# Altium schematic sheet Connector.SchDoc(Connector)
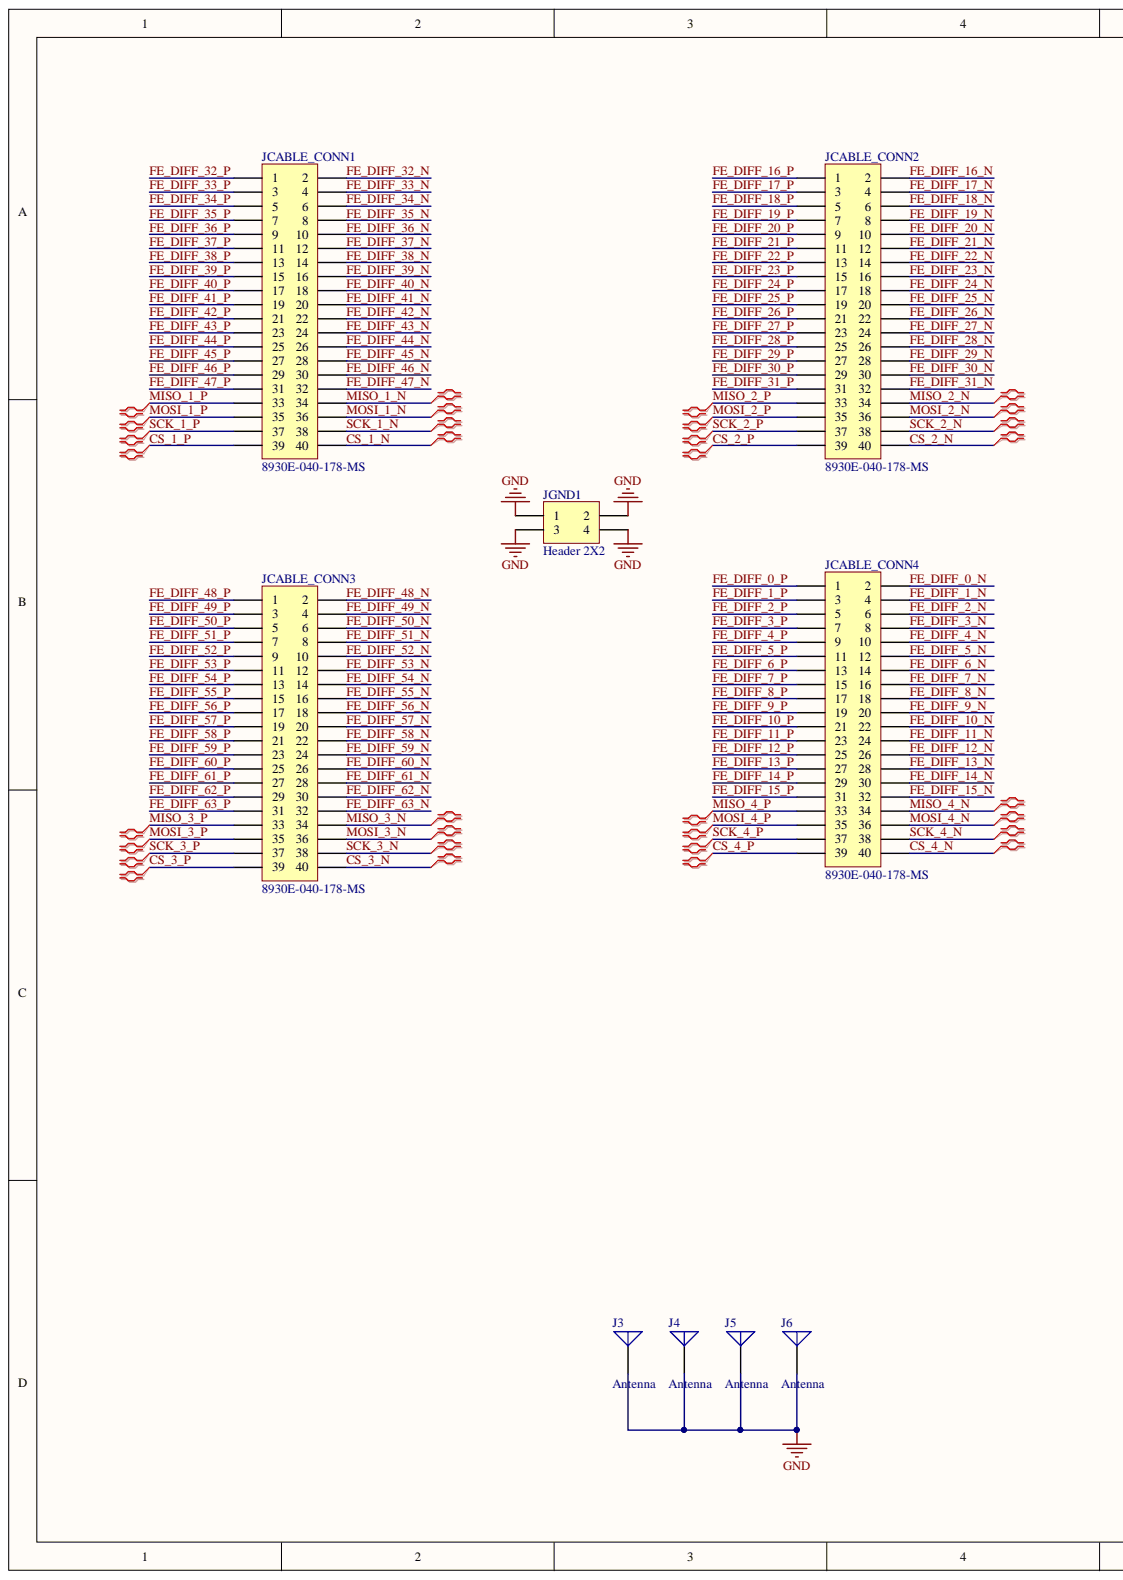
[diagram p0: Connector.SchDoc, left]
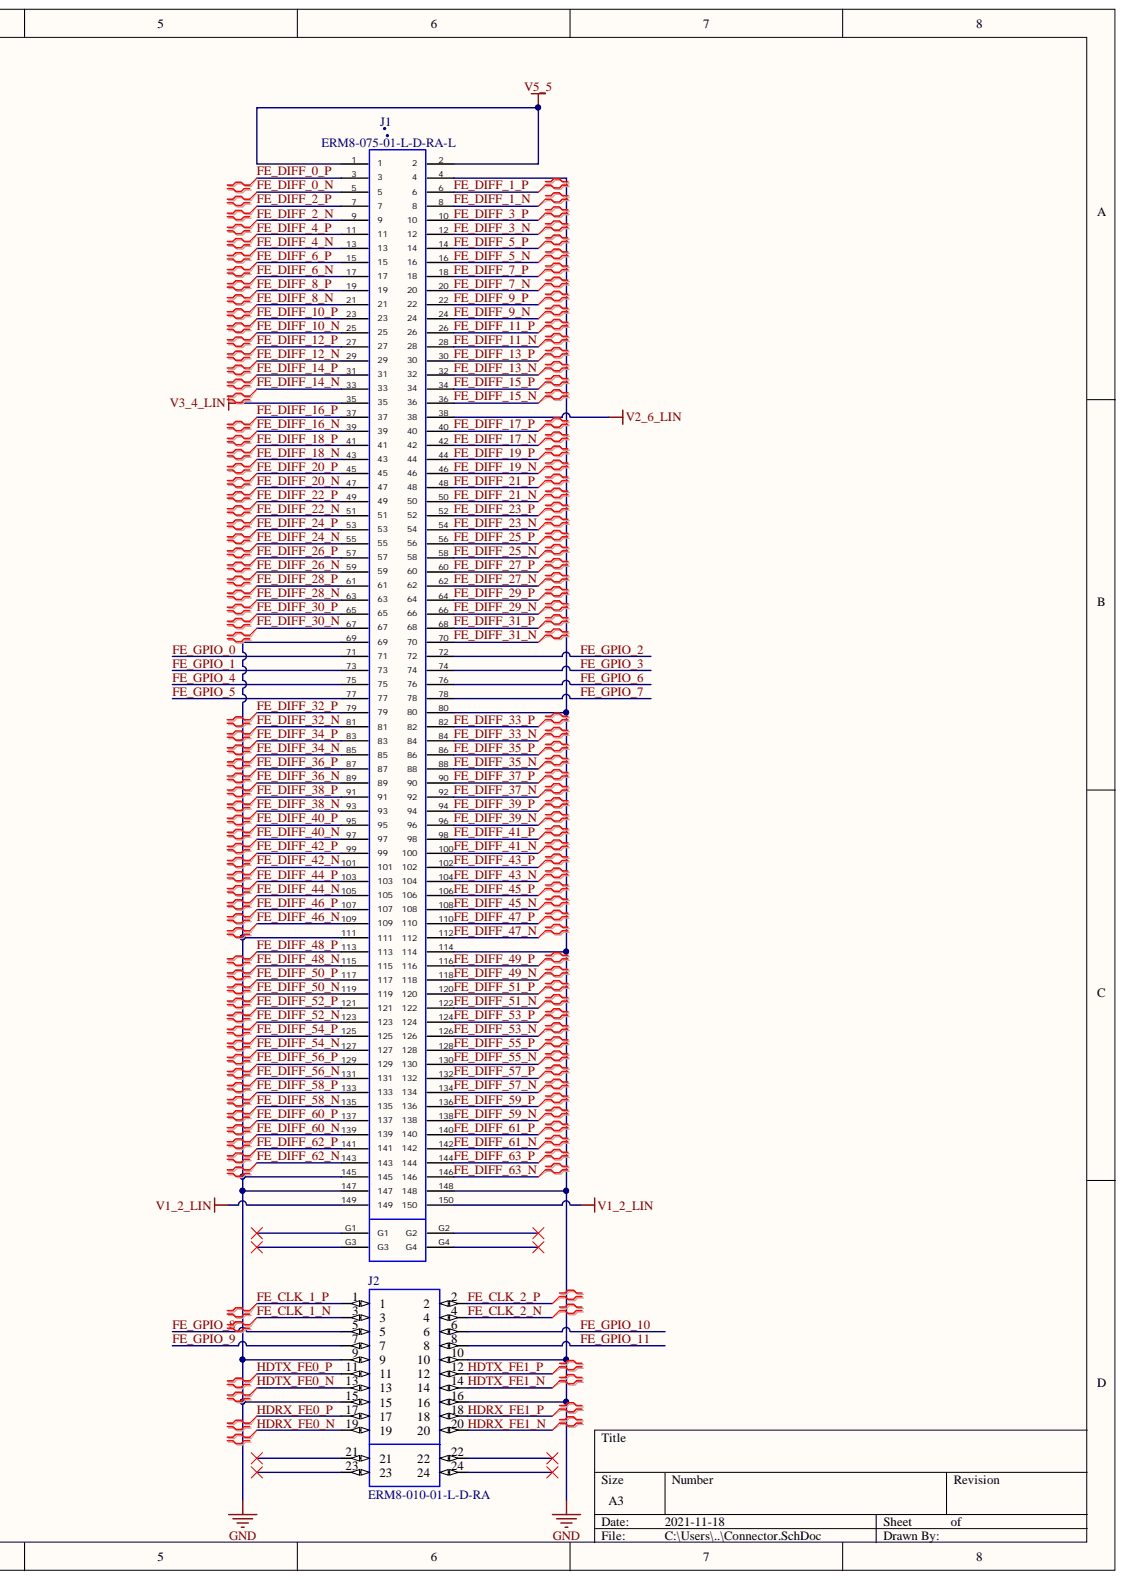
[diagram p1: Connector.SchDoc, right]

## Parts
J1  ERM8-075-01-L-D-RA-L  [SAMTEC-ERM8-075-XX-X-D-RA-L]
J2  ERM8-010-01-L-D-RA  [SAMTEC-ERM8-010-XX-X-D-RA-L]
J3  Antenna  [MTHOLE-DK500D280]
J4  Antenna  [MTHOLE-DK500D280]
J5  Antenna  [MTHOLE-DK500D280]
J6  Antenna  [MTHOLE-DK500D280]
JCABLE_CONN1  8930E-040-178-MS  [KEL-8930E-040-178MS]
JCABLE_CONN2  8930E-040-178-MS  [KEL-8930E-040-178MS]
JCABLE_CONN3  8930E-040-178-MS  [KEL-8930E-040-178MS]
JCABLE_CONN4  8930E-040-178-MS  [KEL-8930E-040-178MS]
JGND1  Header 2X2  [CONMIL100-S-2OE-W200-4]

## Nets
GND: J1.4 J1.69 J1.80 J1.111 J1.114 J1.145 J1.147 J1.148 J2.9 J2.10 J2.15 J2.16 J3.1 J4.1 J5.1 J6.1 JGND1.1 JGND1.2 JGND1.3 JGND1.4
V1_2_LIN: J1.149 J1.150
V2_6_LIN: J1.38
V3_4_LIN: J1.35
V5_5: J1.1 J1.2
CS_1_N: JCABLE_CONN1.40
CS_1_P: JCABLE_CONN1.39
CS_2_N: JCABLE_CONN2.40
CS_2_P: JCABLE_CONN2.39
CS_3_N: JCABLE_CONN3.40
CS_3_P: JCABLE_CONN3.39
CS_4_N: JCABLE_CONN4.40
CS_4_P: JCABLE_CONN4.39
FE_CLK_1_N: J2.3
FE_CLK_1_P: J2.1
FE_CLK_2_N: J2.4
FE_CLK_2_P: J2.2
FE_DIFF_0_N: J1.5 JCABLE_CONN4.2
FE_DIFF_0_P: J1.3 JCABLE_CONN4.1
FE_DIFF_1_N: J1.8 JCABLE_CONN4.4
FE_DIFF_1_P: J1.6 JCABLE_CONN4.3
FE_DIFF_2_N: J1.9 JCABLE_CONN4.6
FE_DIFF_2_P: J1.7 JCABLE_CONN4.5
FE_DIFF_3_N: J1.12 JCABLE_CONN4.8
FE_DIFF_3_P: J1.10 JCABLE_CONN4.7
FE_DIFF_4_N: J1.13 JCABLE_CONN4.10
FE_DIFF_4_P: J1.11 JCABLE_CONN4.9
FE_DIFF_5_N: J1.16 JCABLE_CONN4.12
FE_DIFF_5_P: J1.14 JCABLE_CONN4.11
FE_DIFF_6_N: J1.17 JCABLE_CONN4.14
FE_DIFF_6_P: J1.15 JCABLE_CONN4.13
FE_DIFF_7_N: J1.20 JCABLE_CONN4.16
FE_DIFF_7_P: J1.18 JCABLE_CONN4.15
FE_DIFF_8_N: J1.21 JCABLE_CONN4.18
FE_DIFF_8_P: J1.19 JCABLE_CONN4.17
FE_DIFF_9_N: J1.24 JCABLE_CONN4.20
FE_DIFF_9_P: J1.22 JCABLE_CONN4.19
FE_DIFF_10_N: J1.25 JCABLE_CONN4.22
FE_DIFF_10_P: J1.23 JCABLE_CONN4.21
FE_DIFF_11_N: J1.28 JCABLE_CONN4.24
FE_DIFF_11_P: J1.26 JCABLE_CONN4.23
FE_DIFF_12_N: J1.29 JCABLE_CONN4.26
FE_DIFF_12_P: J1.27 JCABLE_CONN4.25
FE_DIFF_13_N: J1.32 JCABLE_CONN4.28
FE_DIFF_13_P: J1.30 JCABLE_CONN4.27
FE_DIFF_14_N: J1.33 JCABLE_CONN4.30
FE_DIFF_14_P: J1.31 JCABLE_CONN4.29
FE_DIFF_15_N: J1.36 JCABLE_CONN4.32
FE_DIFF_15_P: J1.34 JCABLE_CONN4.31
FE_DIFF_16_N: J1.39 JCABLE_CONN2.2
FE_DIFF_16_P: J1.37 JCABLE_CONN2.1
FE_DIFF_17_N: J1.42 JCABLE_CONN2.4
FE_DIFF_17_P: J1.40 JCABLE_CONN2.3
FE_DIFF_18_N: J1.43 JCABLE_CONN2.6
FE_DIFF_18_P: J1.41 JCABLE_CONN2.5
FE_DIFF_19_N: J1.46 JCABLE_CONN2.8
FE_DIFF_19_P: J1.44 JCABLE_CONN2.7
FE_DIFF_20_N: J1.47 JCABLE_CONN2.10
FE_DIFF_20_P: J1.45 JCABLE_CONN2.9
FE_DIFF_21_N: J1.50 JCABLE_CONN2.12
FE_DIFF_21_P: J1.48 JCABLE_CONN2.11
FE_DIFF_22_N: J1.51 JCABLE_CONN2.14
FE_DIFF_22_P: J1.49 JCABLE_CONN2.13
FE_DIFF_23_N: J1.54 JCABLE_CONN2.16
FE_DIFF_23_P: J1.52 JCABLE_CONN2.15
FE_DIFF_24_N: J1.55 JCABLE_CONN2.18
FE_DIFF_24_P: J1.53 JCABLE_CONN2.17
FE_DIFF_25_N: J1.58 JCABLE_CONN2.20
FE_DIFF_25_P: J1.56 JCABLE_CONN2.19
FE_DIFF_26_N: J1.59 JCABLE_CONN2.22
FE_DIFF_26_P: J1.57 JCABLE_CONN2.21
FE_DIFF_27_N: J1.62 JCABLE_CONN2.24
FE_DIFF_27_P: J1.60 JCABLE_CONN2.23
FE_DIFF_28_N: J1.63 JCABLE_CONN2.26
FE_DIFF_28_P: J1.61 JCABLE_CONN2.25
FE_DIFF_29_N: J1.66 JCABLE_CONN2.28
FE_DIFF_29_P: J1.64 JCABLE_CONN2.27
FE_DIFF_30_N: J1.67 JCABLE_CONN2.30
FE_DIFF_30_P: J1.65 JCABLE_CONN2.29
FE_DIFF_31_N: J1.70 JCABLE_CONN2.32
FE_DIFF_31_P: J1.68 JCABLE_CONN2.31
FE_DIFF_32_N: J1.81 JCABLE_CONN1.2
FE_DIFF_32_P: J1.79 JCABLE_CONN1.1
FE_DIFF_33_N: J1.84 JCABLE_CONN1.4
FE_DIFF_33_P: J1.82 JCABLE_CONN1.3
FE_DIFF_34_N: J1.85 JCABLE_CONN1.6
FE_DIFF_34_P: J1.83 JCABLE_CONN1.5
FE_DIFF_35_N: J1.88 JCABLE_CONN1.8
FE_DIFF_35_P: J1.86 JCABLE_CONN1.7
FE_DIFF_36_N: J1.89 JCABLE_CONN1.10
FE_DIFF_36_P: J1.87 JCABLE_CONN1.9
FE_DIFF_37_N: J1.92 JCABLE_CONN1.12
FE_DIFF_37_P: J1.90 JCABLE_CONN1.11
FE_DIFF_38_N: J1.93 JCABLE_CONN1.14
FE_DIFF_38_P: J1.91 JCABLE_CONN1.13
FE_DIFF_39_N: J1.96 JCABLE_CONN1.16
FE_DIFF_39_P: J1.94 JCABLE_CONN1.15
FE_DIFF_40_N: J1.97 JCABLE_CONN1.18
FE_DIFF_40_P: J1.95 JCABLE_CONN1.17
FE_DIFF_41_N: J1.100 JCABLE_CONN1.20
FE_DIFF_41_P: J1.98 JCABLE_CONN1.19
FE_DIFF_42_N: J1.101 JCABLE_CONN1.22
FE_DIFF_42_P: J1.99 JCABLE_CONN1.21
FE_DIFF_43_N: J1.104 JCABLE_CONN1.24
FE_DIFF_43_P: J1.102 JCABLE_CONN1.23
FE_DIFF_44_N: J1.105 JCABLE_CONN1.26
FE_DIFF_44_P: J1.103 JCABLE_CONN1.25
FE_DIFF_45_N: J1.108 JCABLE_CONN1.28
FE_DIFF_45_P: J1.106 JCABLE_CONN1.27
FE_DIFF_46_N: J1.109 JCABLE_CONN1.30
FE_DIFF_46_P: J1.107 JCABLE_CONN1.29
FE_DIFF_47_N: J1.112 JCABLE_CONN1.32
FE_DIFF_47_P: J1.110 JCABLE_CONN1.31
FE_DIFF_48_N: J1.115 JCABLE_CONN3.2
FE_DIFF_48_P: J1.113 JCABLE_CONN3.1
FE_DIFF_49_N: J1.118 JCABLE_CONN3.4
FE_DIFF_49_P: J1.116 JCABLE_CONN3.3
FE_DIFF_50_N: J1.119 JCABLE_CONN3.6
FE_DIFF_50_P: J1.117 JCABLE_CONN3.5
FE_DIFF_51_N: J1.122 JCABLE_CONN3.8
FE_DIFF_51_P: J1.120 JCABLE_CONN3.7
FE_DIFF_52_N: J1.123 JCABLE_CONN3.10
FE_DIFF_52_P: J1.121 JCABLE_CONN3.9
FE_DIFF_53_N: J1.126 JCABLE_CONN3.12
FE_DIFF_53_P: J1.124 JCABLE_CONN3.11
FE_DIFF_54_N: J1.127 JCABLE_CONN3.14
FE_DIFF_54_P: J1.125 JCABLE_CONN3.13
FE_DIFF_55_N: J1.130 JCABLE_CONN3.16
FE_DIFF_55_P: J1.128 JCABLE_CONN3.15
FE_DIFF_56_N: J1.131 JCABLE_CONN3.18
FE_DIFF_56_P: J1.129 JCABLE_CONN3.17
FE_DIFF_57_N: J1.134 JCABLE_CONN3.20
FE_DIFF_57_P: J1.132 JCABLE_CONN3.19
FE_DIFF_58_N: J1.135 JCABLE_CONN3.22
FE_DIFF_58_P: J1.133 JCABLE_CONN3.21
FE_DIFF_59_N: J1.138 JCABLE_CONN3.24
FE_DIFF_59_P: J1.136 JCABLE_CONN3.23
FE_DIFF_60_N: J1.139 JCABLE_CONN3.26
FE_DIFF_60_P: J1.137 JCABLE_CONN3.25
FE_DIFF_61_N: J1.142 JCABLE_CONN3.28
FE_DIFF_61_P: J1.140 JCABLE_CONN3.27
FE_DIFF_62_N: J1.143 JCABLE_CONN3.30
FE_DIFF_62_P: J1.141 JCABLE_CONN3.29
FE_DIFF_63_N: J1.146 JCABLE_CONN3.32
FE_DIFF_63_P: J1.144 JCABLE_CONN3.31
FE_GPIO_0: J1.71
FE_GPIO_1: J1.73
FE_GPIO_2: J1.72
FE_GPIO_3: J1.74
FE_GPIO_4: J1.75
FE_GPIO_5: J1.77
FE_GPIO_6: J1.76
FE_GPIO_7: J1.78
FE_GPIO_8: J2.5
FE_GPIO_9: J2.7
FE_GPIO_10: J2.6
FE_GPIO_11: J2.8
HDRX_FE0_N: J2.19
HDRX_FE0_P: J2.17
HDRX_FE1_N: J2.20
HDRX_FE1_P: J2.18
HDTX_FE0_N: J2.13
HDTX_FE0_P: J2.11
HDTX_FE1_N: J2.14
HDTX_FE1_P: J2.12
MISO_1_N: JCABLE_CONN1.34
MISO_1_P: JCABLE_CONN1.33
MISO_2_N: JCABLE_CONN2.34
MISO_2_P: JCABLE_CONN2.33
MISO_3_N: JCABLE_CONN3.34
MISO_3_P: JCABLE_CONN3.33
MISO_4_N: JCABLE_CONN4.34
MISO_4_P: JCABLE_CONN4.33
MOSI_1_N: JCABLE_CONN1.36
MOSI_1_P: JCABLE_CONN1.35
MOSI_2_N: JCABLE_CONN2.36
MOSI_2_P: JCABLE_CONN2.35
MOSI_3_N: JCABLE_CONN3.36
MOSI_3_P: JCABLE_CONN3.35
MOSI_4_N: JCABLE_CONN4.36
MOSI_4_P: JCABLE_CONN4.35
SCK_1_N: JCABLE_CONN1.38
SCK_1_P: JCABLE_CONN1.37
SCK_2_N: JCABLE_CONN2.38
SCK_2_P: JCABLE_CONN2.37
SCK_3_N: JCABLE_CONN3.38
SCK_3_P: JCABLE_CONN3.37
SCK_4_N: JCABLE_CONN4.38
SCK_4_P: JCABLE_CONN4.37

Not connected: J1 G1 G2 G3 G4; J2 21 22 23 24

## Library data of the parts
J1 = ERF8-075-XX-X-D-RA-L: 0.8MM PITCH DUAL ROW RIGHT ANGLE SOCKET ASSEMBLY.; MANUFACTURER: SAMTEC; PCB DECAL: ERF8-075-XX-X-D-RA-L; Component Kind: Standard; Library Name: ADDON_LIB_SCH.SchLib; CREATED: October 10, 2015 - Wendy Xin
J2 = ERM8-010-XX-X-D-RA: 0.8MM DUAL ROW RIGHT ANGLE ASSEMBLY; Price: None; Package: None; MP: ERM8-010-XX-X-D-RA; Component Kind: Standard; Availability: Unavailable; Library Name: ADDON_LIB_SCH.SchLib
J3-J6 = Antenna: Generic Antenna; Published: 24-Mar-1999; PackageReference: PIN1; Library Name: Miscellaneous Devices.IntLib; LatestRevisionNote: Re-released for DXP Platform.; LatestRevisionDate: 17-Jul-2002; Component Kind: Standard; Publisher: Altium Limited
JCABLE_CONN1-JCABLE_CONN4 = Header 20X2: Header, 20-Pin, Dual row; Signal Integrity: Connector; Library Name: Miscellaneous Connectors.IntLib; LatestRevisionNote: Re-released for DXP Platform.; LatestRevisionDate: 17-Jul-2002; Component Kind: Standard; Publisher: Altium Limited
JGND1 = Header 2X2: Header, 2-Pin, Dual row; Signal Integrity: Connector; Library Name: Miscellaneous Connectors.IntLib; LatestRevisionNote: Re-released for DXP Platform.; LatestRevisionDate: 17-Jul-2002; Component Kind: Standard; Publisher: Altium Limited
